# Altium schematic sheet Camera+OLED.SchDoc(Camera+OLED)
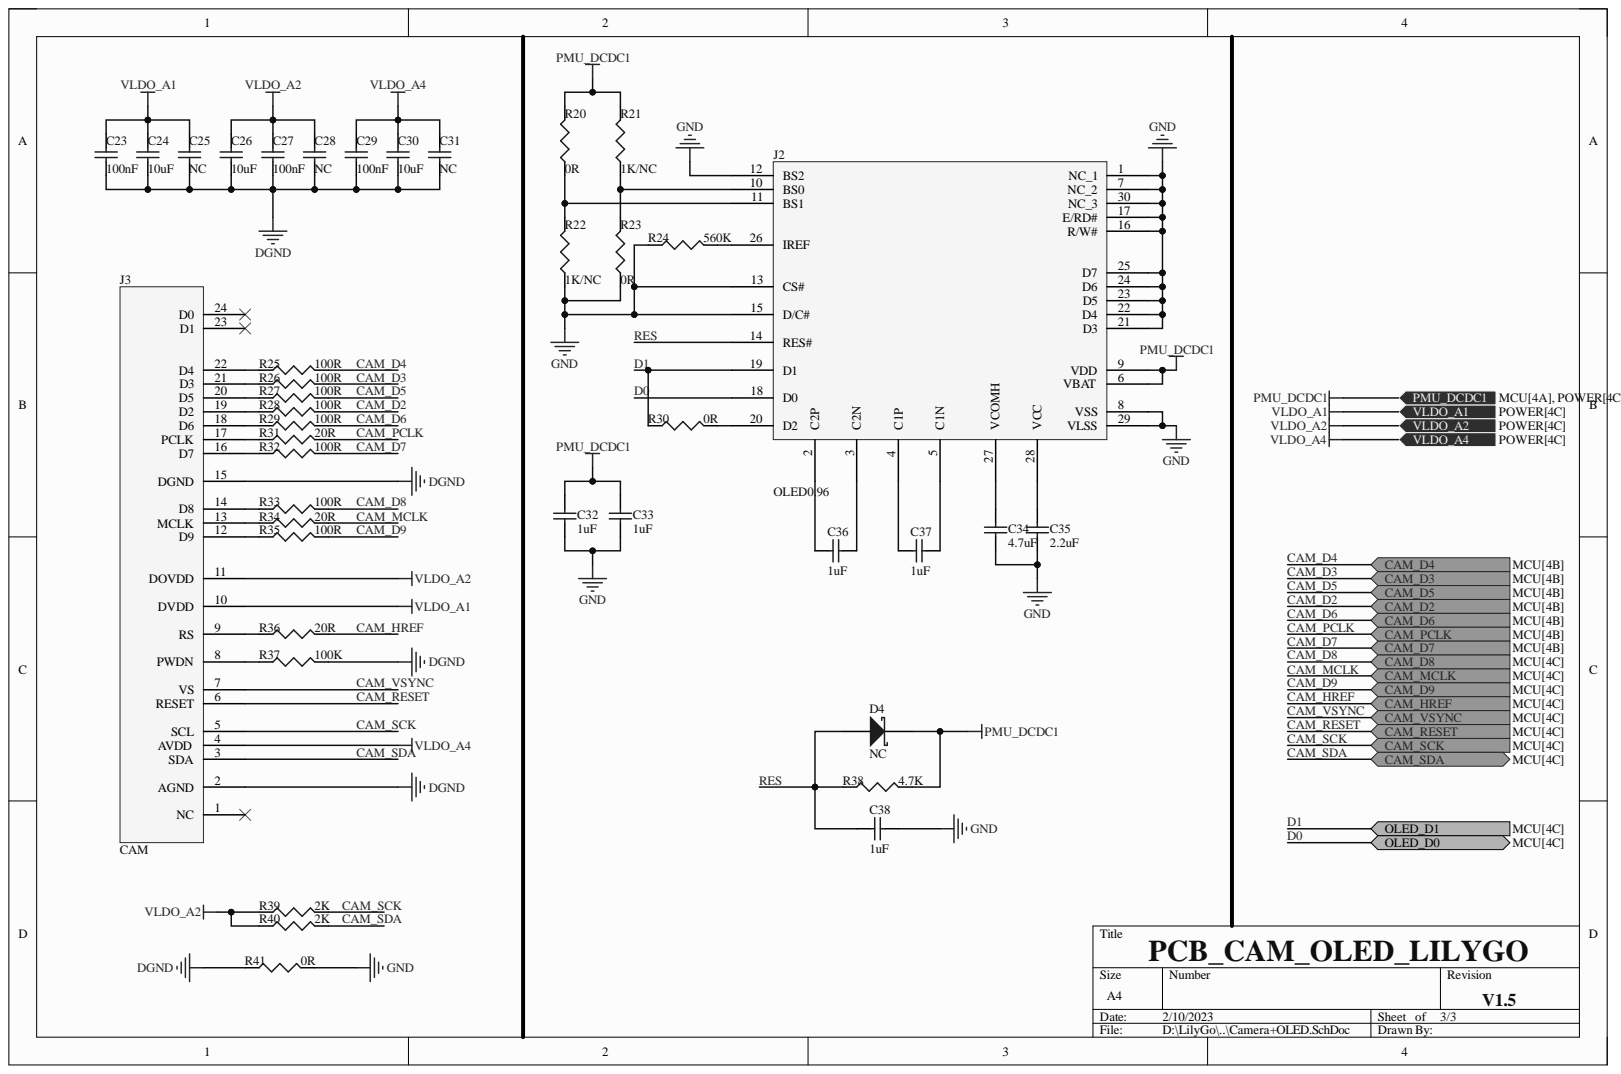
[diagram p0: Camera+OLED.SchDoc]

## Parts
C23  100nF  [C0402]
C24  10uF  [C0603]
C25  NC  [C0402]
C26  10uF  [C0603]
C27  100nF  [C0402]
C28  NC  [C0402]
C29  100nF  [C0402]
C30  10uF  [C0603]
C31  NC  [C0402]
C32  1uF  [C0402]
C33  1uF  [C0402]
C34  4.7uF  [C0402]
C35  2.2uF  [C0402]
C36  1uF  [C0603]
C37  1uF  [C0603]
C38  1uF  [C0402]
D4  NC  [SOD323]
J2  OLED0.96  [OLED_0.96]
J3  CAM  [FPC_24P]
R20  0R  [R0402]
R21  1K/NC  [R0402]
R22  1K/NC  [R0402]
R23  0R  [R0402]
R24  560K  [R0402]
R25  100R  [R0402]
R26  100R  [R0402]
R27  100R  [R0402]
R28  100R  [R0402]
R29  100R  [R0402]
R30  0R  [R0402]
R31  20R  [R0402]
R32  100R  [R0402]
R33  100R  [R0402]
R34  20R  [R0402]
R35  100R  [R0402]
R36  20R  [R0402]
R37  100K  [R0402]
R38  4.7K  [R0402]
R39  2K  [R0402]
R40  2K  [R0402]
R41  0R  [R1206]

## Ports
CAM_D2, CAM_D3, CAM_D4, CAM_D5, CAM_D6, CAM_D7, CAM_D8, CAM_D9, CAM_HREF, CAM_MCLK, CAM_PCLK, CAM_RESET, CAM_SCK, CAM_SDA, CAM_VSYNC, OLED_D0, OLED_D1, PMU_DCDC1, VLDO_A1, VLDO_A2, VLDO_A4

## Nets
DGND: C23.2 C24.2 C25.2 C26.2 C27.2 C28.2 C29.2 C30.2 C31.2 J3.2(AGND) J3.15(DGND) R37.2 R41.1
GND: C32.1 C33.1 C34.2 C35.2 C38.2 J2.1(NC_1) J2.7(NC_2) J2.8(VSS) J2.12(BS2) J2.13(CS#) J2.15(D/C#) J2.16(R/W#) J2.17(E/RD#) J2.21(D3) J2.22(D4) J2.23(D5) J2.24(D6) J2.25(D7) J2.29(VLSS) J2.30(NC_3) R22.1 R23.1 R24.1 R41.2
CAM_D2: R28.2 ; port CAM_D2
CAM_D3: R26.2 ; port CAM_D3
CAM_D4: R25.2 ; port CAM_D4
CAM_D5: R27.2 ; port CAM_D5
CAM_D6: R29.2 ; port CAM_D6
CAM_D7: R32.2 ; port CAM_D7
CAM_D8: R33.2 ; port CAM_D8
CAM_D9: R35.2 ; port CAM_D9
CAM_HREF: R36.2 ; port CAM_HREF
CAM_MCLK: R34.2 ; port CAM_MCLK
CAM_PCLK: R31.2 ; port CAM_PCLK
CAM_RESET: J3.6(RESET) ; port CAM_RESET
CAM_SCK: J3.5(SCL) R39.2 ; port CAM_SCK
CAM_SDA: J3.3(SDA) R40.2 ; port CAM_SDA
CAM_VSYNC: J3.7(VS) ; port CAM_VSYNC
D0: J2.18(D0) ; port OLED_D0
D1: J2.19(D1) R30.1 ; port OLED_D1
PMU_DCDC1: C32.2 C33.2 D4.2 J2.6(VBAT) J2.9(VDD) R20.2 R21.2 R38.2 ; port PMU_DCDC1
RES: C38.1 D4.1 J2.14(RES#) R38.1
VLDO_A1: C23.1 C24.1 C25.1 J3.10(DVDD) ; port VLDO_A1
VLDO_A2: C26.1 C27.1 C28.1 J3.11(DOVDD) R39.1 R40.1 ; port VLDO_A2
VLDO_A4: C29.1 C30.1 C31.1 J3.4(AVDD) ; port VLDO_A4
NetC34_1: C34.1 J2.27(VCOMH)
NetC35_1: C35.1 J2.28(VCC)
NetC36_1: C36.1 J2.2(C2P)
NetC36_2: C36.2 J2.3(C2N)
NetC37_1: C37.1 J2.4(C1P)
NetC37_2: C37.2 J2.5(C1N)
NetJ2_10: J2.10(BS0) R21.1 R23.2
NetJ2_11: J2.11(BS1) R20.1 R22.2
NetJ2_20: J2.20(D2) R30.2
NetJ2_26: J2.26(IREF) R24.2
NetJ3_8: J3.8(PWDN) R37.1
NetJ3_9: J3.9(RS) R36.1
NetJ3_12: J3.12(D9) R35.1
NetJ3_13: J3.13(MCLK) R34.1
NetJ3_14: J3.14(D8) R33.1
NetJ3_16: J3.16(D7) R32.1
NetJ3_17: J3.17(PCLK) R31.1
NetJ3_18: J3.18(D6) R29.1
NetJ3_19: J3.19(D2) R28.1
NetJ3_20: J3.20(D5) R27.1
NetJ3_21: J3.21(D3) R26.1
NetJ3_22: J3.22(D4) R25.1

Not connected: J3 1(NC) 23(D1) 24(D0)

## Library data of the parts
C23-C38 = Cap: Capacitor; Component Kind: Standard; LatestRevisionDate: 17-Jul-2002; LatestRevisionNote: Re-released for DXP Platform.; Library Name: Miscellaneous Devices.IntLib; PackageDescription: Radial Cap, Thru-Hole; 2 Leads; 0.3 in Pin Spacing; PackageReference: RAD-0.3; Published: 8-Jun-2000; Signal Integrity: Cap; Simulation: CAP; Publisher: Altium Limited
  C23 C27 C29: Value: 100nF
  C24 C26 C30: Value: 10uF
  C25 C28 C31: Value: NC
  C32 C33 C36-C38: Value: 1uF
  C34: Value: 4.7uF
  C35: Value: 2.2uF
D4 = D Schottky: Schottky Diode; Code_JEDEC: DO-214-AA; Component Kind: Standard; LatestRevisionDate: 17-Jul-2002; LatestRevisionNote: Re-released for DXP Platform.; Library Name: Miscellaneous Devices.IntLib; PackageDescription: DO-214-AA/SMB; 2 C-Bend Leads; Body 5.3 x 3.6 mm, inc. leads (LxW); PackageReference: SMB; Published: 8-Jun-2000; Signal Integrity: D Schottky; Simulation: SKYDIODE; Publisher: Altium Limited
J3 = PIN24_CAM: Component Kind: Standard; Library Name: MySchlib.SchLib
R20-R41 = Res3: Resistor; Component Kind: Standard; LatestRevisionDate: 29-May-2009; LatestRevisionNote: IPC-7351 Footprint Added.; Library Name: Miscellaneous Devices.IntLib; PackageDescription: Chip Resistor; PackageReference: J1-0603; Published: 8-Jun-2000; Signal Integrity: Res; Simulation: RESISTOR; Publisher: Altium Limited
  R20 R23 R30 R41: Value: 0R
  R21 R22: Value: 1K/NC
  R24: Value: 560K
  R25-R29 R32 R33 R35: Value: 100R
  R31 R34 R36: Value: 20R
  R37: Value: 100K
  R38: Value: 4.7K
  R39 R40: Value: 2K
J2 = OLED0.96: Component Kind: Standard; Library Name: MySchlib.SchLib
